# Altium schematic sheet Pag1_PowerSupply.SchDoc(Pag1_PowerSupply)
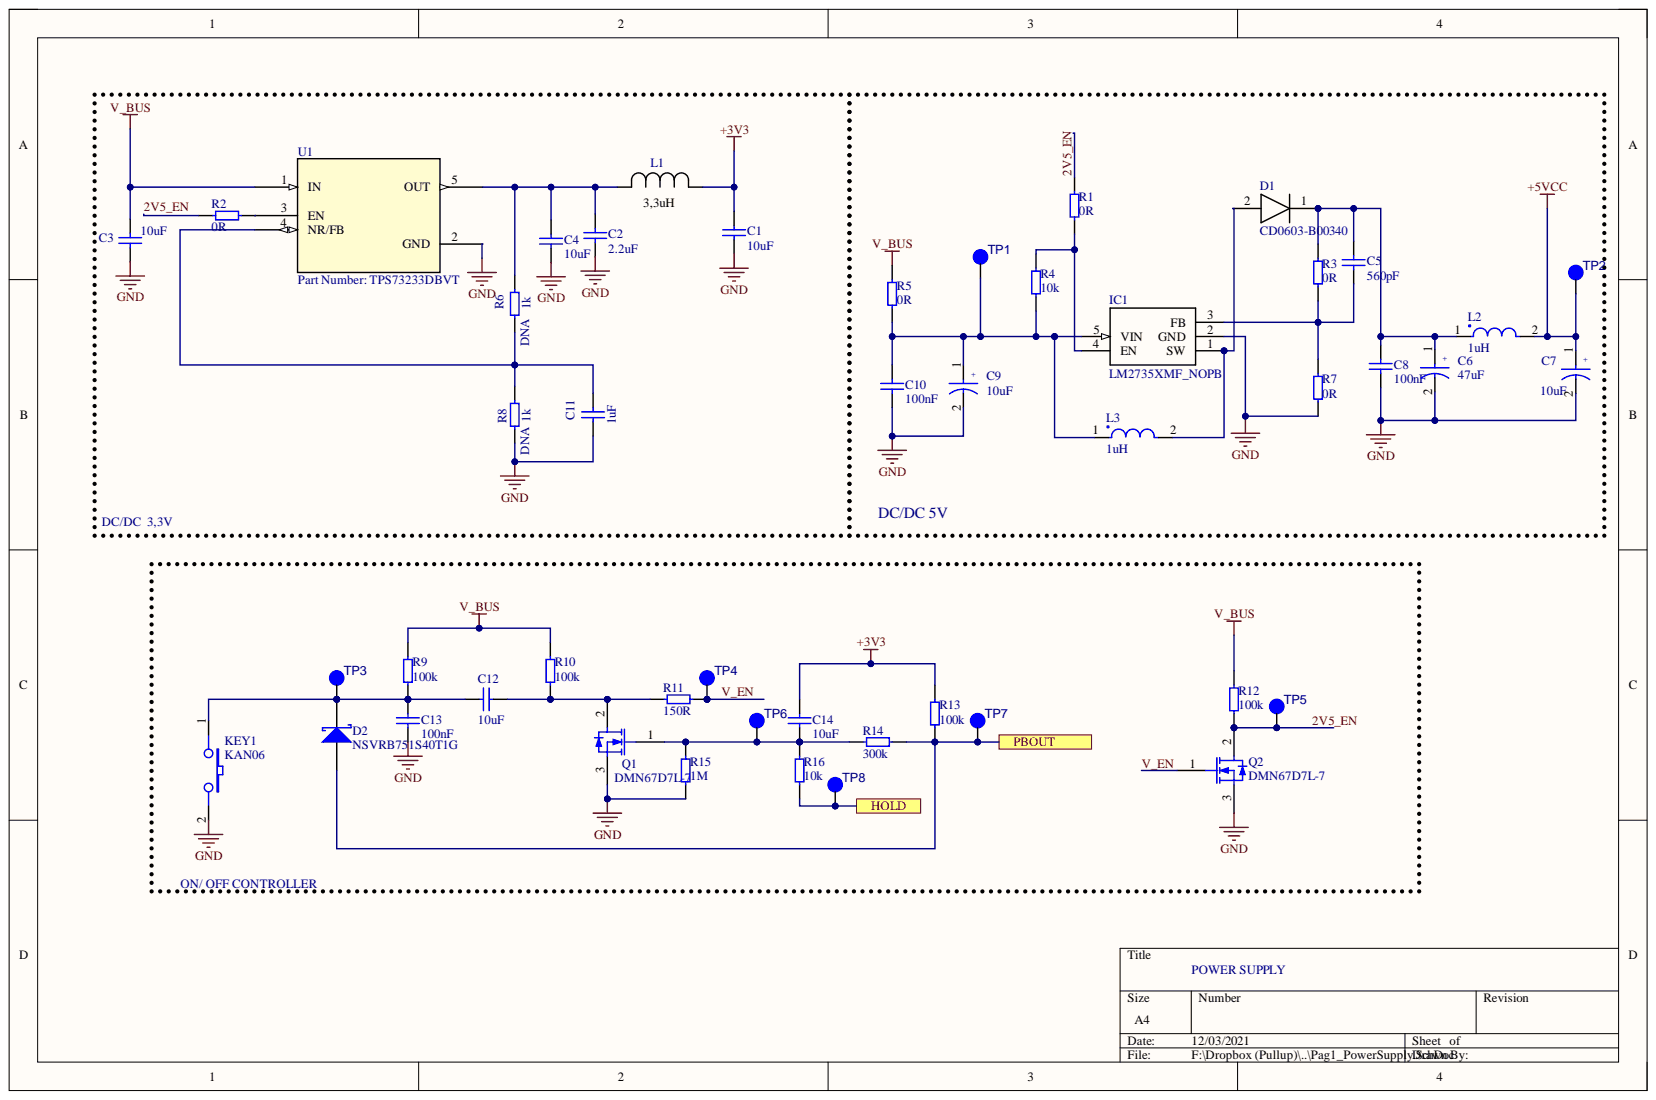
[diagram p0: Pag1_PowerSupply.SchDoc]

## Parts
C1  10uF  [0603]
C2  2.2uF  [0603]
C3  10uF  [0603]
C4  10uF  [0603]
C5  560pF  [0603]
C6  47uF  [CAP_6.6X6.6]
C7  10uF  [CAP_6.6X6.6]
C8  100nF  [0603]
C9  10uF  [CAP_6.6X6.6]
C10  100nF  [0603]
C11  1uF  [0603]
C12  10uF  [0603]
C13  100nF  [0603]
C14  10uF  [0603]
D1  CD0603-B00340  [DIOM179X85N]
D2  NSVRB751S40T1G  [D_SOD523]
IC1  LM2735XMF_NOPB  [SOT95P280X145-5N]
KEY1  KAN06  [KAN06_v2]
L1  value 3,3uH  [IND_2012]  (hidden comment: Part Number: MLZ2012A3R3WT000)
L2  1uH  [SRP4012TA]
L3  1uH  [SRP4012TA]
Q1  DMN67D7L-7  [D_SOT23]
Q2  DMN67D7L-7  [D_SOT23]
R1  0R  [0603]
R2  0R  [0603]
R3  0R  [0603]
R4  10k  [0603]
R5  0R  [0603]
R6  1k  PCBA: DNA  [0603]
R7  0R  [0603]
R8  1k  PCBA: DNA  [0603]
R9  100k  [0603]
R10  100k  [0603]
R11  150R  [0603]
R12  100k  [0603]
R13  100k  [0603]
R14  300k  [0603]
R15  1M  [0603]
R16  10k  [0603]
TP1  =value  [TEST]
TP2  =value  [TEST]
TP3  =value  [TEST]
TP4  =value  [TEST]
TP5  =value  [TEST]
TP6  =value  [TEST]
TP7  =value  [TEST]
TP8  =value  [TEST]
U1  Part Number: TPS73233DBVT  [DBV5]

## Ports
HOLD, PBOUT

## Nets
+3V3: C1.2 C14.1 L1.1 R13.2
+5VCC: C7.1 L2.2 TP2.1
GND: C1.1 C2.1 C3.1 C4.1 C6.2 C7.2 C8.1 C9.2 C10.1 C11.1 C13.1 IC1.2(GND) KEY1.2 Q1.3 Q2.3 R7.1 R8.2 R15.2 U1.2(GND)
V_BUS: C3.2 R5.2 R9.2 R10.2 R12.2 U1.1(IN)
2V5_EN: Q2.2 R1.2 R2.2 R12.1 TP5.1
HOLD: R16.2 TP8.1 ; port HOLD
PBOUT: D2.A R13.1 R14.2 TP7.1 ; port PBOUT
V_EN: Q2.1 R11.1 TP4.1
NetC2_2: C2.2 C4.2 L1.2 R6.1 U1.5(OUT)
NetC5_1: C5.1 IC1.3(FB) R3.1 R7.2
NetC5_2: C5.2 C6.1 C8.2 D1.1 L2.1 R3.2
NetC9_1: C9.1 C10.2 IC1.5(VIN) L3.1 R4.1 R5.1 TP1.1
NetC11_2: C11.2 R6.2 R8.1 U1.4(NR/FB)
NetC12_1: C12.1 Q1.2 R10.1 R11.2
NetC12_2: C12.2 C13.2 D2.K KEY1.1 R9.1 TP3.1
NetC14_2: C14.2 Q1.1 R14.1 R15.1 R16.1 TP6.1
NetD1_2: D1.2 IC1.1(SW) L3.2
NetIC1_4: IC1.4(EN) R1.1 R4.2
NetR2_1: R2.1 U1.3(EN)

## Library data of the parts
C1 C4 = Cap: Manufacturer: Murata; MPN: GRM188R61A106KE69J; PCBA: Assembly; Assembly: Assembly; Component Kind: Standard; Datasheet: https://www.mouser.com/datasheet/2/281/murata_03052018_GRM_Series_1-1310166.pdf; Supplier 1: Mouser; Supplier 1 Link: https://www.mouser.com/ProductDetail/Murata-Electronics/GRM188R61A106KE69J?qs=sGAEpiMZZMs0AnBnWHyRQAsAWwhBCY7bp3pFrpMtukFBX6VOqeHlKw%3D%3D; Supplier 1 Part Number: 81-GRM188R61A106KE9J; Value: 10uF; Library Name: LIBSCH_PULLUP.SchLib
C2 = CAP_1uF: Manufacturer: Wurth Electronics; MPN: 885012106018; Complement Value: 16V; Database Table Name: Capacitor$; PCBA: Assembly; Assembly: Assembly; Component Kind: Standard; Datasheet: https://www.mouser.com/datasheet/2/445/885012106018-1727438.pdf; Supplier 1: Mouser; Supplier 1 Link: https://www.mouser.com/ProductDetail/Wurth-Elektronik/885012106018?qs=0KOYDY2FL2%252BOT%2FT4XCDUXg%3D%3D; Supplier 1 Part Number: 710-885012106018; Value: 2.2uF; Library Name: Database_Pullup.DbLib
C3 = CAP_1uF: Manufacturer: Wurth Electronics; MPN: C0805C106K8PACTU; Complement Value: 16V; Database Table Name: Capacitor$; PCBA: Assembly; Assembly: Assembly; Component Kind: Standard; Datasheet: https://www.mouser.com/datasheet/2/212/KEM_C1006_X5R_SMD-1103249.pdf; Supplier 1: Mouser; Supplier 1 Link: https://www.mouser.com/ProductDetail/KEMET/C0805C106K8PACTU?qs=sGAEpiMZZMs0AnBnWHyRQI9zuYIiQALCxT7NLyqb6iU%3D; Supplier 1 Part Number: 80-C0805C106K8P; Value: 10uF; Library Name: Database_Pullup.DbLib
C5 C8 C10 C13 = (no symbol name): Capacitor Ceramic 100nF 10% 16V 0603 (1608 Metric); Manufacturer: Kemet; MPN: C0603C104K4RACTU; Alternate: AA; CPqD PN: Pullup_Cap_100nF_0603_CAP; Engineering Change Status Code: Approved; Extra Info: - 55 C to + 125 C; LeadTime: 12 wks; Model_File: CAP; PCB INFO: Assembly; Temperature Coefficient: X7R; Complement Value: 16V; Component Kind: Standard; Component Type: Standard; Package: 0603 (1608 Metric); Price: 0,012; RoHS: SIM; Size / Dimension: 0.8 mm W x 1.6 mm L x 0.8 mm H; SVN_Link Data Sheet: http://svn.cpqd.com.br/DRT/biblio_comp/tags/datasheets/capacitor/kemet.pdf; Tolerance: 10%; Type: Capacitor Ceramic; Value: 100nF; Web_Link Data Sheet: http://www.kemet.com/kemet/web/homepage/kechome.nsf/vapubfiles/KEM_C1002_X7R_SMD.pdf/$file/KEM_C1002_X7R_SMD.pdf; Library Name: LIBSCH_PULLUP.SchLib
C6 C7 C9 = (no symbol name): Capacitor Electrolytic 47µF 20% 16V; Manufacturer: Nichicon; MPN: UWT1C470MCL1GB; Alternate: AA; CPqD PN: Pullup_Cap_47µF_CAP_6.6X6.6_Cap Pol; Engineering Change Status Code: Pending; Extra Info: - 55 C to + 105 C; LeadTime: 18 wks; Model_File: CAP; PCB INFO: Assembly; Complement Value: 16V; Component Kind: Standard; Component Type: Standard; Price: 0,135; RoHS: SIM; Size / Dimension: 6.3 mm Dia. x 6.6 mm L x 6.6 mm H; Tolerance: 20%; Type: Capacitor Electrolytic; Value: 47µF; Web_Link Data Sheet: http://www.nichicon.co.jp/english/products/pdfs/e-wt.pdf; Library Name: LIBSCH_PULLUP (Cópia em conflito de Engenharia Pullup 2018-04-18).SchLib
C11 = CAP_1uF: Manufacturer: Wurth Electronics; MPN: 885012106022; Complement Value: 16V; Database Table Name: Capacitor$; PCBA: Assembly; Assembly: Assembly; Component Kind: Standard; Datasheet: https://www.mouser.com/datasheet/2/445/885012106022-553988.pdf; Supplier 1: Mouser; Supplier 1 Link: https://www.mouser.com/ProductDetail/Wurth-Electronics/885012106022?qs=sGAEpiMZZMvsSlwiRhF8qunkWFWGyo%252bv58Az%2fdOka1LULsFo4E2qgw%3d%3d; Supplier 1 Part Number: 710-885012106022; Value: 1uF; Library Name: Database_Pullup.DbLib
C12 = Cap: MPN: CL10A106KP8NQSC; Complement: 10V; PCBA: Assembly; Component Kind: Standard; Library Name: SchLib1.SchLib; Supplier: Mouser; Supplier Link: https://www.mouser.com/ProductDetail/Samsung-Electro-Mechanics/CL10A106KP8NQSC/?qs=sGAEpiMZZMvsSlwiRhF8qvCY8MbTG5UF19c5q%252B5VvTs%3D; Supplier PN: 187-CL10A106KP8NQSC; Value: 10uF
C14 = Cap: MPN: CL10A106KP8NQSC; PCBA: Assembly; Component Kind: Standard; Library Name: SchLib1.SchLib; Supplier: Mouser; Supplier Link: https://www.mouser.com/ProductDetail/Samsung-Electro-Mechanics/CL10A106KP8NQSC/?qs=sGAEpiMZZMvsSlwiRhF8qvCY8MbTG5UF19c5q%252B5VvTs%3D; Supplier PN: 187-CL10A106KP8NQSC; Value: 10uF
D1 = CD0603-B00340: Diode; Manufacturer_Name: Bourns; Arrow Part Number: CD0603-B00340; Arrow Price/Stock: https://www.arrow.com/en/products/cd0603-b00340/bourns; Component Kind: Standard; Datasheet Link: https://datasheet.datasheetarchive.com/originals/distributors/Datasheets-DGA23/1390916.pdf; Height: 0.85mm; Library Name: CD0603.SchLib; Manufacturer_Part_Number: CD0603-B00340; Mouser Part Number: 652-CD0603-B00340; Mouser Price/Stock: https://www.mouser.com/Search/Refine.aspx?Keyword=652-CD0603-B00340
D2 = Schottky Diode: PCBA: Assembly; Component Kind: Standard; Library Name: SchLib1.SchLib; MPN: NSVRB751S40T1G; Supplier: Mouser; Supplier Link: https://www.mouser.com/ProductDetail/ON-Semiconductor/NSVRB751S40T1G/?qs=tCMd4XlZ%2FiAyTi7d3L3ZqA%3D%3D; Supplier PN: 863-NSVRB751S40T1G; Value: NSVRB751S40T1G
IC1 = LM2735XMF_NOPB: Integrated Circuit; Manufacturer_Name: Texas Instruments; Manufacturer_Part_Number: LM2735XMF/NOPB; Arrow Part Number: LM2735XMF/NOPB; Arrow Price/Stock: https://www.arrow.com/en/products/lm2735xmfnopb/texas-instruments?region=nac; Component Kind: Standard; Datasheet Link: http://www.ti.com/lit/ds/symlink/lm2735.pdf; Height: 1.45mm; Library Name: LM2735.SchLib; Mouser Part Number: 926-LM2735XMF/NOPB; Mouser Price/Stock: https://www.mouser.co.uk/ProductDetail/Texas-Instruments/LM2735XMF-NOPB?qs=X1J7HmVL2ZGWlm%2F0hOdpKw%3D%3D
KEY1 = SDS: Manufacturer: Metaltex; PCBA: Assembly; Component Kind: Standard; Datasheet: www.metaltex.com.br/assets/produtos/pdf/a0.pdf; MPN: KAN06; Supplier 1: Metaltex; Supplier 1 Part Number: KAN06; Value: KAN06; Library Name: LIBSCH_PULLUP.SchLib
L1 = MLZ2012A3R3WT000: No Description Available; Manufacturer: TDK; PCBA: Assembly; Assembly: Assembly; Component Kind: Standard; Datasheet: https://www.mouser.com/datasheet/2/400/nductor_commercial_decoupling_mlz2012_en-918301.pdf; MPN: MLZ2012A3R3WT000; Supplier 1: Mouser; Supplier 1 Link: https://www.mouser.com/ProductDetail/TDK/MLZ2012A3R3WT000?qs=sGAEpiMZZMsg%252By3WlYCkU4Ucsz4Og40lN9cahkTj2eE%3D; Supplier 1 Part Number: 810-MLZ2012A3R3WT000; Library Name: LIBSCH_PULLUP.SchLib
L2 L3 = (no symbol name): Manufacturer: Bourns; MPN: SRP4012TA-1R0M; PCBA: Assembly; Component Kind: Standard; Datasheet: https://www.mouser.com/datasheet/2/54/rp4012ta-1391620.pdf; Supplier 1: Mouser; Supplier 1 Link: https://www.mouser.com/ProductDetail/Bourns/SRP4012TA-1R0M?qs=%2Fha2pyFaduhnZC9XlWRPjS9IbC2g%252Bs6L7AFI%2FwucAauXoSPPWYzFLg%3D%3D; Supplier 1 Part Number: 652-SRP4012TA-1R0M; Value: 1uH; Library Name: potenciostato.SCHLIB
Q1 Q2 = MOSFET N-Ch: PCBA: Assembly; Component Kind: Standard; Library Name: SchLib1.SchLib; MPN: DMN67D7L-7; Supplier: Mouser; Supplier Link: https://www.mouser.com/ProductDetail/Diodes-Incorporated/DMN67D7L-7/?qs=W0yvOO0ixfEtOtSMB8B7pA%3D%3D; Supplier PN: 621-DMN67D7L-7; Value: DMN67D7L-7
R1 R3-R5 R7 R9 R10 = (no symbol name): Resistor Thick Film 1k 1% 0.1W, 1/10W 0603 (1608 metric) +/- 100 PPM / K; Manufacturer: Vishay; MPN: CRCW06031K00FKEA; Alternate: AA; CPqD PN: Pullup_Res_1k_0603_RES; Engineering Change Status Code: Approved; Extra Info: - 55 C to + 155 C; LeadTime: 12 wks; Model_File: RES; PCB INFO: Assembly; Projeto Pullup: na; Complement Value: 0.1W, 1/10W; Component Kind: Standard; Component Type: Standard; Package: 0603 (1608 metric); Price: 0,006; RoHS: SIM; Size / Dimension: 0.85 mm W x 1.55 mm L x 0.45 mm H; SVN_Link Data Sheet: http://svn.cpqd.com.br/DRT/biblio_comp/tags/datasheets/resistor/Vishay_CRCW.pdf; Temperature Coefficient: +/- 100 PPM / K; Tolerance: 1%; Type: Resistor Thick Film; Value: 1k; Web_Link Data Sheet: http://www.vishay.com/docs/20035/dcrcwe3.pdf; Library Name: LIBSCH_PULLUP.SchLib
R2 = Res: MPN: CR0603-JW-151ELF; PCBA: Assembly; Value: 150R; Component Kind: Standard; Library Name: SchLib1.SchLib; Supplier: Mouser; Supplier Link: https://www.mouser.com/ProductDetail/Bourns/CR0603-JW-151ELF/?qs=sGAEpiMZZMvdGkrng054t%252BUcv6lYODj35J3%2FZubT2cc%3D; Supplier PN: 652-CR0603-JW-151ELF
R6 R8 = Pullup_Res_1k_0603_RES: Manufacturer: Yageo; MPN: RC0603JR-071KL; Complement Value: 0.1W, 1/10W; Database Table Name: Resistores$; Assembly: Assembly; Component Kind: Standard; Datasheet: https://www.mouser.com/datasheet/2/447/PYu-RC_Group_51_RoHS_L_10-1527934.pdf; Library Name: Database_Pullup_New.DbLib; Supplier 1: Mouser; Supplier 1 Link: https://www.mouser.com/ProductDetail/Yageo/RC0603JR-071KL?qs=sGAEpiMZZMu61qfTUdNhG5eFuApKbqVd0r5fNalZWmI%3d; Supplier 1 Part Number: 603-RC0603JR-071KL; Value: 1k
R11 = Res: MPN: CR0603-JW-151ELF; PCBA: Assembly; Component Kind: Standard; Library Name: SchLib1.SchLib; Supplier: Mouser; Supplier Link: https://www.mouser.com/ProductDetail/Bourns/CR0603-JW-151ELF/?qs=sGAEpiMZZMvdGkrng054t%252BUcv6lYODj35J3%2FZubT2cc%3D; Supplier PN: 652-CR0603-JW-151ELF; Value: 150R
R12 R13 = Res: MPN: RC0603FR-07100KL; PCBA: Assembly; Component Kind: Standard; Library Name: SchLib1.SchLib; Supplier: Mouser; Supplier Link: https://www.mouser.com/ProductDetail/Yageo/RC0603FR-07100KL/?qs=sGAEpiMZZMvdGkrng054t0Ql6vgZwrVhhtMMAnph%2FzU%3D; Supplier PN: 603-RC0603FR-07100KL; Value: 100k
R14 = Res: MPN: RC0603FR-07300KL; PCBA: Assembly; Component Kind: Standard; Library Name: SchLib1.SchLib; Supplier: Mouser; Supplier Link: https://www.mouser.com/ProductDetail/Yageo/RC0603FR-07300KL/?qs=sGAEpiMZZMvdGkrng054t3pr%252BPE%2FADjsBeMbDi72CZ0%3D; Supplier PN: 603-RC0603FR-07300KL; Value: 300k
R15 = Res: MPN: RC0603FR-071ML; PCBA: Assembly; Component Kind: Standard; Library Name: SchLib1.SchLib; Supplier: Mouser; Supplier Link: https://www.mouser.com/ProductDetail/Yageo/RC0603FR-071ML/?qs=sGAEpiMZZMvdGkrng054t3pr%252BPE%2FADjsD43MFxJGzcQ%3D; Supplier PN: 603-RC0603FR-071ML; Value: 1M
R16 = Res: MPN: RC0603FR-0710KL; PCBA: Assembly; Component Kind: Standard; Library Name: SchLib1.SchLib; Supplier: Mouser; Supplier Link: https://www.mouser.com/ProductDetail/Yageo/RC0603FR-0710KL/?qs=sGAEpiMZZMvdGkrng054t0Ql6vgZwrVhDmPP4HS%2FwqE%3D; Supplier PN: 603-RC0603FR-0710KL; Value: 10k
TP1-TP8 = TESTPOINT: Component Kind: Standard; Library Name: SchLib1.SchLib
U1 = TPS73225DBVT: No Description Available; Manufacturer: Texas Instruments; MPN: TPS73233DBVR; PCBA: Assembly; Value: TPS73233DBVR; Assembly: Assembly; Component Kind: Standard; Datasheet: http://www.ti.com/lit/ds/symlink/tps732.pdf; Supplier 1: Mouser; Supplier 1 Link: https://www.mouser.com/ProductDetail/Texas-Instruments/TPS73233DBVR?qs=sGAEpiMZZMsGz1a6aV8DcPXeWoVS0FnzvGIkReIEIPE%3D; Supplier 1 Part Number: 595-TPS73233DBVR; Library Name: LIBSCH_PULLUP.SchLib
